# Altium schematic sheet [27] - PWR II.SchDoc("[27] - PWR II")
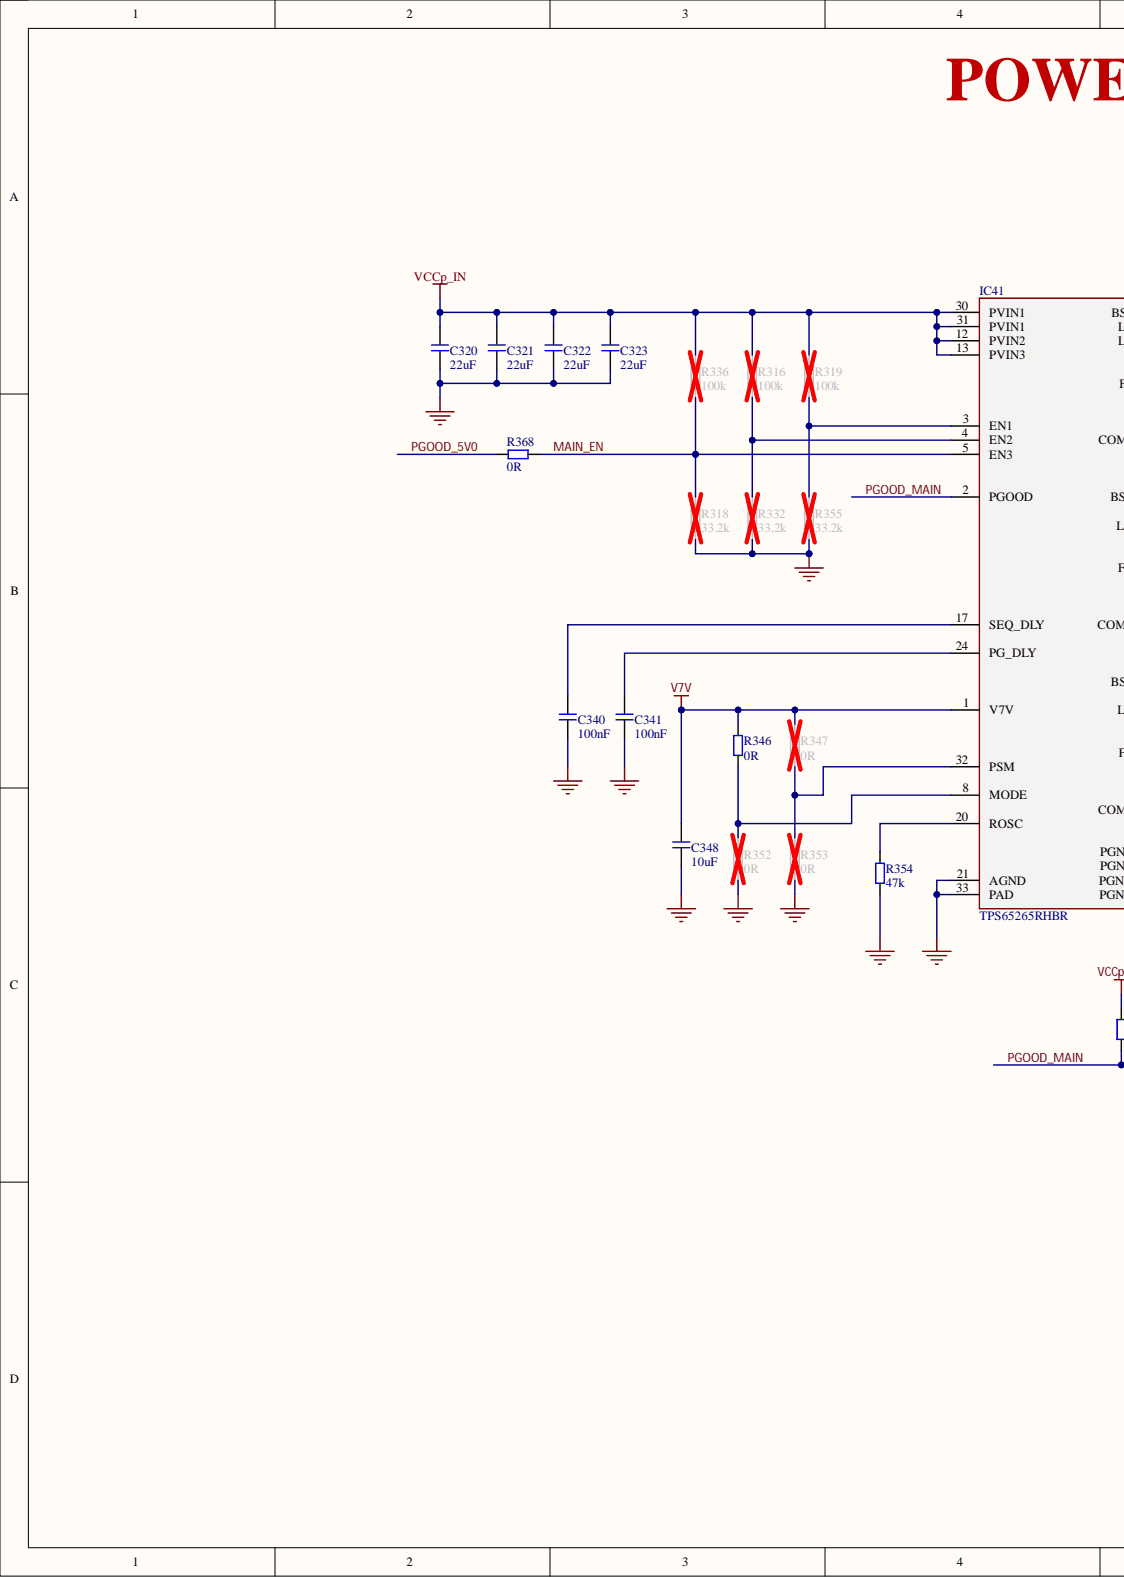
[diagram p0: [27] - PWR II.SchDoc, left]
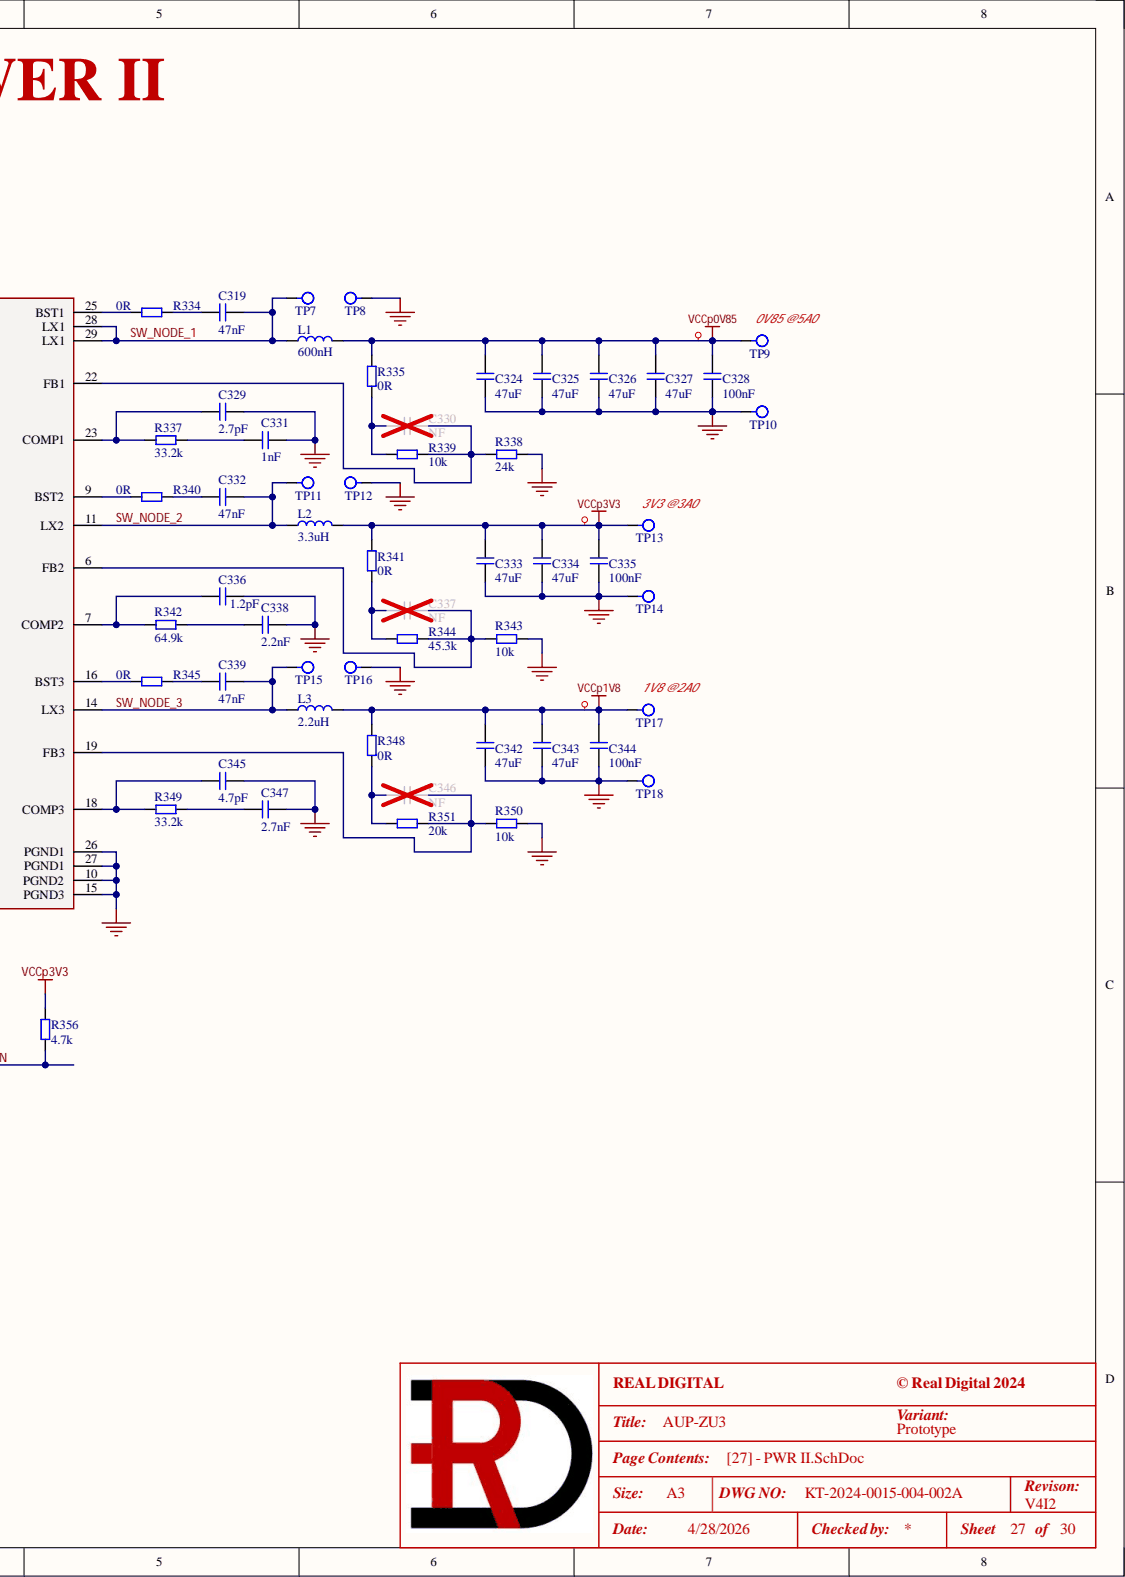
[diagram p1: [27] - PWR II.SchDoc, right]

## Parts
C319  47nF  [C0402]
C320  22uF  [C0805]
C321  22uF  [C0805]
C322  22uF  [C0805]
C323  22uF  [C0805]
C324  47uF  [C1210]
C325  47uF  [C1210]
C326  47uF  [C1210]
C327  47uF  [C1210]
C328  100nF  [C0402]
C329  2.7pF  [C0402]
C330  NF  [C0402]
C331  1nF  [C0402]
C332  47nF  [C0402]
C333  47uF  [C1210]
C334  47uF  [C1210]
C335  100nF  [C0402]
C336  1.2pF  [C0402]
C337  NF  [C0402]
C338  2.2nF  [C0402]
C339  47nF  [C0402]
C340  100nF  [C0402]
C341  100nF  [C0402]
C342  47uF  [C1210]
C343  47uF  [C1210]
C344  100nF  [C0402]
C345  4.7pF  [C0402]
C346  NF  [C0402]
C347  2.7nF  [C0402]
C348  10uF  [C0805]
IC41  TPS65265RHBR  [RHB0032E_VQFN-32 - TI]
L1  600nH  [XAL5030]
L2  3.3uH  [XAL5030]
L3  2.2uH  [XAL5030]
R316  100k  [R0402]
R318  33.2k  [R0402]
R319  100k  [R0402]
R332  33.2k  [R0402]
R334  0R  [R0402]
R335  0R  [R0402]
R336  100k  [R0402]
R337  33.2k  [R0402]
R338  24k  [R0402]
R339  10k  [R0402]
R340  0R  [R0402]
R341  0R  [R0402]
R342  64.9k  [R0402]
R343  10k  [R0402]
R344  45.3k  [R0402]
R345  0R  [R0402]
R346  0R  [R0402]
R347  0R  [R0402]
R348  0R  [R0402]
R349  33.2k  [R0402]
R350  10k  [R0402]
R351  20k  [R0402]
R352  0R  [R0402]
R353  0R  [R0402]
R354  47k  [R0402]
R355  33.2k  [R0402]
R356  4.7k  [R0402]
R368  0R  [R0402]
TP7  1mm  [TP_1MM]  (value not drawn)  (no BOM)
TP8  1mm  [TP_1MM]  (value not drawn)  (no BOM)
TP9  1.5mm  [TP_1.5MM]  (value not drawn)  (no BOM)
TP10  1.5mm  [TP_1.5MM]  (value not drawn)  (no BOM)
TP11  1mm  [TP_1MM]  (value not drawn)  (no BOM)
TP12  1mm  [TP_1MM]  (value not drawn)  (no BOM)
TP13  1.5mm  [TP_1.5MM]  (value not drawn)  (no BOM)
TP14  1.5mm  [TP_1.5MM]  (value not drawn)  (no BOM)
TP15  1mm  [TP_1MM]  (value not drawn)  (no BOM)
TP16  1mm  [TP_1MM]  (value not drawn)  (no BOM)
TP17  1.5mm  [TP_1.5MM]  (value not drawn)  (no BOM)
TP18  1.5mm  [TP_1.5MM]  (value not drawn)  (no BOM)

## Nets
GND: C320.1 C321.1 C322.1 C323.1 C324.1 C325.1 C326.1 C327.1 C328.1 C329.2 C331.2 C333.1 C334.1 C335.1 C336.2 C338.2 C340.1 C341.1 C342.1 C343.1 C344.1 C345.2 C347.2 C348.1 IC41.10(PGND2) IC41.15(PGND3) IC41.21(AGND) IC41.26(PGND1) IC41.27(PGND1) IC41.33(PAD) R318.1 R332.1 R338.2 R343.1 R350.1 R352.2 R353.2 R354.1 R355.1 TP8.1 TP10.1 TP12.1 TP14.1 TP16.1 TP18.1
V7V: C348.2 IC41.1(V7V) R346.1 R347.1
VCCp0V85: C324.2 C325.2 C326.2 C327.2 C328.2 L1.2 R335.2 TP9.1
VCCp1V8: C342.2 C343.2 C344.2 L3.2 R348.1 TP17.1
VCCp3V3: C333.2 C334.2 C335.2 L2.2 R341.1 R356.2 TP13.1
VCCp_IN: C320.2 C321.2 C322.2 C323.2 IC41.12(PVIN2) IC41.13(PVIN3) IC41.30(PVIN1) IC41.31(PVIN1) R316.2 R319.2 R336.2
MAIN_EN: IC41.5(EN3) R318.2 R336.1 R368.1
PGOOD_5V0: R368.2
PGOOD_MAIN: IC41.2(PGOOD) R356.1
SW_NODE_1: C319.1 IC41.28(LX1) IC41.29(LX1) L1.1 TP7.1
SW_NODE_2: C332.1 IC41.11(LX2) L2.1 TP11.1
SW_NODE_3: C339.1 IC41.14(LX3) L3.1 TP15.1
NetC319_2: C319.2 R334.1
NetC329_1: C329.1 IC41.23(COMP1) R337.1
NetC330_1: C330.1 R335.1 R339.2
NetC330_2: C330.2 IC41.22(FB1) R338.1 R339.1
NetC331_1: C331.1 R337.2
NetC332_2: C332.2 R340.1
NetC336_1: C336.1 IC41.7(COMP2) R342.1
NetC337_1: C337.1 R341.2 R344.2
NetC337_2: C337.2 IC41.6(FB2) R343.2 R344.1
NetC338_1: C338.1 R342.2
NetC339_2: C339.2 R345.1
NetC340_2: C340.2 IC41.17(SEQ_DLY)
NetC341_2: C341.2 IC41.24(PG_DLY)
NetC345_1: C345.1 IC41.18(COMP3) R349.1
NetC346_1: C346.1 R348.2 R351.2
NetC346_2: C346.2 IC41.19(FB3) R350.2 R351.1
NetC347_1: C347.1 R349.2
NetIC41_3: IC41.3(EN1) R319.1 R355.2
NetIC41_4: IC41.4(EN2) R316.1 R332.2
NetIC41_8: IC41.8(MODE) R346.2 R352.1
NetIC41_9: IC41.9(BST2) R340.2
NetIC41_16: IC41.16(BST3) R345.2
NetIC41_20: IC41.20(ROSC) R354.2
NetIC41_25: IC41.25(BST1) R334.2
NetIC41_32: IC41.32(PSM) R347.2 R353.1

## Library data of the parts
C319 C332 C339 = GRM155R71E473KA88J: CAP CER 0.047uF 25V X7R 0402; Manufacturer 1: Murata Electronics; .Checked_PCB: AC; .Creator: RV; C P/N: 111-0286; LoadStatus: Load; Tolerance: 10%; Category: Capacitors; Component Kind: Standard; Library Name: KT-RealDigital.IntLib; Manufacturer 1 Part Number: GRM155R71E473KA88J; Temperature Coefficient: X7R; Voltage - Rated: 25V; .Checked_SCH: AC
C320-C323 = GRM21BR61E226ME44K: CAP CER 22uF 25V X5R 0805; Manufacturer 1: Murata Electronics; .Checked_PCB: RV; C P/N: RD-111-0047; LoadStatus: Load; Tolerance: 20%; Category: Capacitors; Component Kind: Standard; Library Name: KT-RealDigital.IntLib; Manufacturer 1 Part Number: GRM21BR61E226ME44K; Temperature Coefficient: X5R; Voltage - Rated: 25V; .Checked_SCH: RV; .Creator: RV
C324-C327 C333 C334 C342 C343 = GRM32EC81C476KE15K: CAP CER 47uF 16V X6S 1210; Manufacturer 1: Murata Electronics; Manufacturer 2 Part Number: GRM32EC81C476KE15L; .Checked_PCB: AC; .Creator: RV; C P/N: RD-111-0007; LoadStatus: Load; Tolerance: 10%; Category: Capacitors; Component Kind: Standard; Library Name: KT-RealDigital.IntLib; Manufacturer 1 Part Number: GRM32EC81C476KE15K; Manufacturer 2: Murata Electronics; Temperature Coefficient: X6S; Voltage - Rated: 16V; .Checked_SCH: AC
C328 C335 C344 = GRM155R71E104KE14J: CAP CER 0.1uF 25V X7R 0402; Manufacturer 1: Murata Electronics; .Checked_PCB: AC; .Creator: RV; C P/N: RD-111-0006; LoadStatus: Load; Tolerance: 10%; Category: Capacitors; Component Kind: Standard; Library Name: KT-RealDigital.IntLib; Manufacturer 1 Part Number: GRM155R71E104KE14J; Temperature Coefficient: X7R; Voltage - Rated: 25V; .Checked_SCH: AC
C329 = GRM1555C1H2R7CA01D: CAP CER 2.7pF 50V C0G/NP0 0402; Manufacturer 1: Murata Electronics; .Checked_PCB: RV; C P/N: RD-111-0100; LoadStatus: Load; Temperature Coefficient: C0G, NP0; Tolerance: 0.25pF; Category: Capacitors; Component Kind: Standard; Library Name: KT-RealDigital.IntLib; Manufacturer 1 Part Number: GRM1555C1H2R7CA01D; Voltage - Rated: 50V; .Checked_SCH: RV; .Creator: RV
C330 C337 C346 = !CAP0402: Generic Capacitor, 0402; .Creator: RV; LoadStatus: Load; Category: Capacitors; Component Kind: Standard; Library Name: KT-RealDigital.IntLib
C331 = GRM1555C1H102JA01D: CAP CER 1000pF 50V C0G/NP0 0402; Manufacturer 1: Murata Electronics; .Checked_PCB: RV; C P/N: RD-111-0048; LoadStatus: Load; Temperature Coefficient: C0G, NP0; Tolerance: 5%; Category: Capacitors; Component Kind: Standard; Library Name: KT-RealDigital.IntLib; Manufacturer 1 Part Number: GRM1555C1H102JA01D; Voltage - Rated: 50V; .Checked_SCH: RV; .Creator: RV
C336 = GJM1555C1H1R2CB01D: CAP CER 1.2pF 50V C0G/NP0 0402; Manufacturer 1: Murata Electronics; .Checked_PCB: AC; .Creator: RV; C P/N: RD-111-0012; LoadStatus: Load; Temperature Coefficient: C0G, NP0; Tolerance: 0.25pF; Category: Capacitors; Component Kind: Standard; Library Name: KT-RealDigital.IntLib; Manufacturer 1 Part Number: GJM1555C1H1R2CB01D; Voltage - Rated: 50V; .Checked_SCH: AC
C338 = GRM155R71H222JA01J: CAP CER 2200pF 50V X7R 0402; Manufacturer 1: Murata Electronics; .Checked_PCB: RV; C P/N: RD-111-0028; LoadStatus: Load; Tolerance: 5%; Category: Capacitors; Component Kind: Standard; Library Name: KT-RealDigital.IntLib; Manufacturer 1 Part Number: GRM155R71H222JA01J; Temperature Coefficient: X7R; Voltage - Rated: 50V; .Checked_SCH: RV; .Creator: RV
C340 C341 = GRM155R71H104ME14J: CAP CER 0.1uF 50V X7R 0402; Manufacturer 1: Murata Electronics; .Checked_PCB: DG; .Creator: RV; C P/N: RD-111-0069; LoadStatus: Load; Tolerance: 20%; Category: Capacitors; Component Kind: Standard; Library Name: KT-RealDigital.IntLib; Manufacturer 1 Part Number: GRM155R71H104ME14J; Temperature Coefficient: X7R; Voltage - Rated: 50V; .Checked_SCH: DG
C345 = GRM1555C1H4R7CA01D: CAP CER 4.7pF 50V C0G/NP0 0402; Manufacturer 1: Murata Electronics; .Checked_PCB: RV; C P/N: RD-111-0101; LoadStatus: Load; Temperature Coefficient: C0G, NP0; Tolerance: 0.25pF; Category: Capacitors; Component Kind: Standard; Library Name: KT-RealDigital.IntLib; Manufacturer 1 Part Number: GRM1555C1H4R7CA01D; Voltage - Rated: 50V; .Checked_SCH: RV; .Creator: RV
C347 = GRM155R71H272KA01J: CAP CER 2700pF 50V X7R 0402; Manufacturer 1: Murata Electronics; .Checked_PCB: RV; C P/N: RD-111-0097; LoadStatus: Load; Tolerance: 10%; Category: Capacitors; Component Kind: Standard; Library Name: KT-RealDigital.IntLib; Manufacturer 1 Part Number: GRM155R71H272KA01J; Temperature Coefficient: X7R; Voltage - Rated: 50V; .Checked_SCH: RV; .Creator: RV
C348 = GRM21BR71A106KA73L: CAP CER 10uF 10V X7R 0805; Manufacturer 1: Murata Electronics; .Checked_PCB: AC; .Creator: RV; C P/N: 111-0789; LoadStatus: Load; Tolerance: 10%; Category: Capacitors; Component Kind: Standard; Library Name: KT-RealDigital.IntLib; Manufacturer 1 Part Number: GRM21BR71A106KA73L; Temperature Coefficient: X7R; Voltage - Rated: 10V; .Checked_SCH: AC
IC41 = TPS65265RHBR: 4.5- to 17-V Input Voltage, 5-A/3-A/2-A Output Current Triple Buck Converter; Manufacturer 1: Texas Instruments; .Checked_PCB: RV; C P/N: RD-126-1521; LoadStatus: Load; Category: IC; Component Kind: Standard; Library Name: KT-RealDigital.IntLib; Manufacturer 1 Part Number: TPS65265RHBR; .Checked_SCH: RV; .Creator: RV
L1 = XAL5030-601ME: Fixed Inductors 600nH Shld 20% 17.7A 4.52mOhms AECQ2; Manufacturer 1: Coilcraft; .Checked_PCB: RV; C P/N: RD-131-0040; LoadStatus: Load; Category: Inductors; Component Kind: Standard; Library Name: KT-RealDigital.IntLib; Manufacturer 1 Part Number: XAL5030-601ME; .Checked_SCH: RV; .Creator: RV
L2 = XAL5030-332MEC: Fixed Inductors 3.3uH Shld 20% 8.1A 23.3mOhms AECQ2; Manufacturer 1: Coilcraft; .Checked_PCB: RV; C P/N: RD-131-0039; LoadStatus: Load; Category: Inductors; Component Kind: Standard; Library Name: KT-RealDigital.IntLib; Manufacturer 1 Part Number: XAL5030-332MEC; .Checked_SCH: RV; .Creator: RV
L3 = XAL5030-222MEC: Fixed Inductors 2.2uH Shld 20% 9.2A 14.5mOhms AECQ2; Manufacturer 1: Coilcraft; .Checked_PCB: RV; C P/N: RD-131-0043; LoadStatus: Load; Category: Inductors; Component Kind: Standard; Library Name: KT-RealDigital.IntLib; Manufacturer 1 Part Number: XAL5030-222MEC; .Checked_SCH: RV; .Creator: RV
R316 R319 R336 = RC0402FR-07100KL: RES SMD 100K OHM 1% 1/16W 0402; Manufacturer 1: Yageo; .Checked_PCB: RV; C P/N: RD-101-0013; LoadStatus: Load; Tolerance: 1%/TK100; Category: Resistors; Component Kind: Standard; Library Name: KT-RealDigital.IntLib; Manufacturer 1 Part Number: RC0402FR-07100KL; .Checked_SCH: RV; .Creator: RV
R318 R332 R337 R349 R355 = RC0402FR-0733K2L: RES 33.2K OHM 1% 1/16W 0402; Manufacturer 1: YAGEO; .Checked_PCB: RV; C P/N: 101-0566; LoadStatus: Load; Tolerance: 1%/TK100; Category: Resistors; Component Kind: Standard; Library Name: KT-RealDigital.IntLib; Manufacturer 1 Part Number: RC0402FR-0733K2L; .Checked_SCH: RV; .Creator: RV
R334 R335 R340 R341 R345-R348 R352 R353 R368 = RC0402FR-070RL: RES SMD 0 OHM JUMPER 1/16W 0402; Manufacturer 1: YAGEO; .Checked_PCB: AC; .Creator: RV; C P/N: RD-101-0003; LoadStatus: Load; Category: Resistors; Component Kind: Standard; Library Name: KT-RealDigital.IntLib; Manufacturer 1 Part Number: RC0402FR-070RL; Tolerance: Jumper; .Checked_SCH: AC
R338 = RT0402BRD0724KL: RES SMD 24K OHM 0.1% 1/16W 0402; Manufacturer 1: YAGEO; .Checked_PCB: RV; C P/N: 101-1535; LoadStatus: Load; Tolerance: 0.1%/TK25; Category: Resistors; Component Kind: Standard; Library Name: KT-RealDigital.IntLib; Manufacturer 1 Part Number: RT0402BRD0724KL; .Checked_SCH: RV; .Creator: RV
R339 R343 R350 = RT0402BRB0710KL: RES SMD 10K OHM 0.1% 1/16W 0402; Manufacturer 1: YAGEO; .Checked_PCB: AC; .Creator: RV; C P/N: 101-0862; LoadStatus: Load; Tolerance: 0.1%/TK10; Category: Resistors; Component Kind: Standard; Library Name: KT-RealDigital.IntLib; Manufacturer 1 Part Number: RT0402BRB0710KL; .Checked_SCH: AC
R342 = RC0402FR-0764K9L: RES 64.9K OHM 1% 1/16W 0402; Manufacturer 1: YAGEO; .Checked_PCB: RV; C P/N: 101-0145; LoadStatus: Load; Tolerance: 1%/TK100; Category: Resistors; Component Kind: Standard; Library Name: KT-RealDigital.IntLib; Manufacturer 1 Part Number: RC0402FR-0764K9L; .Checked_SCH: RV; .Creator: RV
R344 = RT0402BRD0745K3L: RES SMD 45.3KOHM 0.1% 1/16W 0402; Manufacturer 1: Yageo; .Checked_PCB: AC; .Creator: RV; C P/N: RD-101-0004; LoadStatus: Load; Tolerance: 0.1%/TK25; Category: Resistors; Component Kind: Standard; Library Name: KT-RealDigital.IntLib; Manufacturer 1 Part Number: RT0402BRD0745K3L; .Checked_SCH: AC
R351 = RT0402BRD0720KL: RES SMD 20K OHM 0.1% 1/16W 0402; Manufacturer 1: Yageo; .Checked_PCB: AC; .Creator: RV; C P/N: 101-1361; LoadStatus: Load; Tolerance: 0.1%/TK25; Category: Resistors; Component Kind: Standard; Library Name: KT-RealDigital.IntLib; Manufacturer 1 Part Number: RT0402BRD0720KL; .Checked_SCH: AC
R354 = RC0402JR-0747KL: RES SMD 47K OHM 5% 1/16W 0402; Manufacturer 1: Yageo; .Checked_PCB: AC; .Creator: RV; C P/N: 101-0074; LoadStatus: Load; Tolerance: 5%/TK100; Category: Resistors; Component Kind: Standard; Library Name: KT-RealDigital.IntLib; Manufacturer 1 Part Number: RC0402JR-0747KL; .Checked_SCH: AC
R356 = RC0402FR-074K7P: RES SMD 4.7K OHM 1% 1/16W 0402; Manufacturer 1: Yageo; .Checked_PCB: AC; .Creator: RV; C P/N: 101-0129; LoadStatus: Load; Tolerance: 1%/TK100; Category: Resistors; Component Kind: Standard; Library Name: KT-RealDigital.IntLib; Manufacturer 1 Part Number: RC0402FR-074K7P; .Checked_SCH: AC
TP7 TP8 TP11 TP12 TP15 TP16 = TEST_POINT_1mm: TEST POINT round 1mm; Manufacturer 1: NA; .Checked_PCB: RV; LoadStatus: Load; Category: Test Point; Library Name: KT-RealDigital.IntLib; Manufacturer 1 Part Number: NA; Manufacturer 2: NA; Manufacturer 2 Part Number: NA; .Checked_SCH: RV; .Creator: RV
TP9 TP10 TP13 TP14 TP17 TP18 = TEST_POINT_1.5mm: TEST POINT - Round 1.5mm; Manufacturer 1: NA; .Checked_PCB: RV; LoadStatus: Load; Category: Test Point; Library Name: KT-RealDigital.IntLib; Manufacturer 1 Part Number: NA; Manufacturer 2: NA; Manufacturer 2 Part Number: NA; .Checked_SCH: RV; .Creator: RV
